# Altium schematic sheet TipWiring_alt.SchDoc
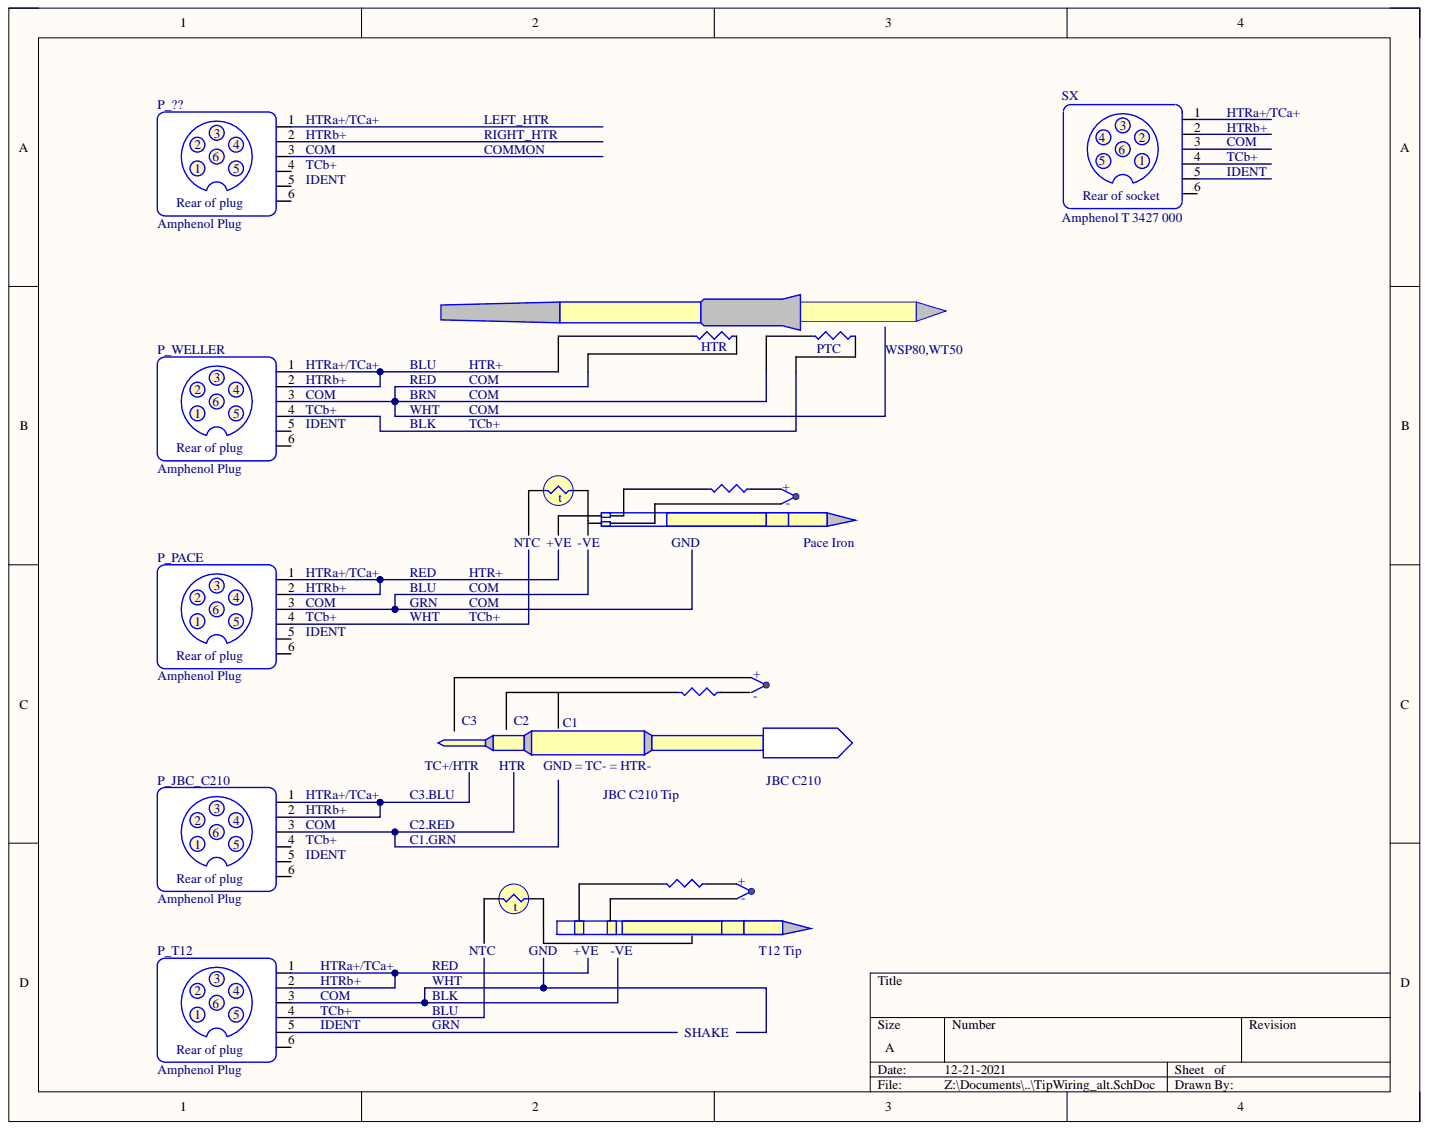
[diagram p0: TipWiring_alt.SchDoc]

## Parts
JBC_C210_Tip  JBC C210  (mechanical)
P_??  Amphenol Plug  (mechanical)
P_JBC_C210  Amphenol Plug  (mechanical)
P_PACE  Amphenol Plug  (mechanical)
P_T12  Amphenol Plug  (mechanical)
P_WELLER  Amphenol Plug  (mechanical)
Pace_Iron  Pace  (mechanical)
SX  Amphenol T 3427 000  (mechanical)
T12_Tip  T12 Tip  (mechanical)
WSP80,WT50  WELLER WSP80  (value not drawn)  (mechanical)

## Nets
NetP_JBC_C210_1: P_JBC_C210.1 P_JBC_C210.2
NetP_PACE_1: P_PACE.1 P_PACE.2
NetP_T12_1: P_T12.1 P_T12.2
NetP_WELLER_1: P_WELLER.1 P_WELLER.2

Not connected: P_?? 1 2 3 4 5 6; P_JBC_C210 3 4 5 6; P_PACE 3 4 5 6; P_T12 3 4 5 6; P_WELLER 3 4 5 6; SX 1 2 3 4 5 6(Rear_of_socket)

## Library data of the parts
P_?? P_JBC_C210 P_PACE P_T12 P_WELLER = Amphenol Plug: Circular Connector, C091B Series, Panel Mount Receptacle, 6 Contacts, Solder Socket, Metal Body; Library Name: MySchLib.schlib
SX = Amphenol T 3427 000: Circular Connector, C091B Series, Panel Mount Receptacle, 6 Contacts, Solder Socket, Metal Body; Library Name: MySchLib.schlib
JBC_C210_Tip = JBC C210: Library Name: MySchLib.schlib
Pace_Iron = Pace: Library Name: MySchLib.schlib
T12_Tip = T12 Tip: Library Name: MySchLib.schlib
WSP80,WT50 = WELLER WSP80: Library Name: MySchLib.schlib
